# Altium schematic sheet 接口.SchDoc(接口)
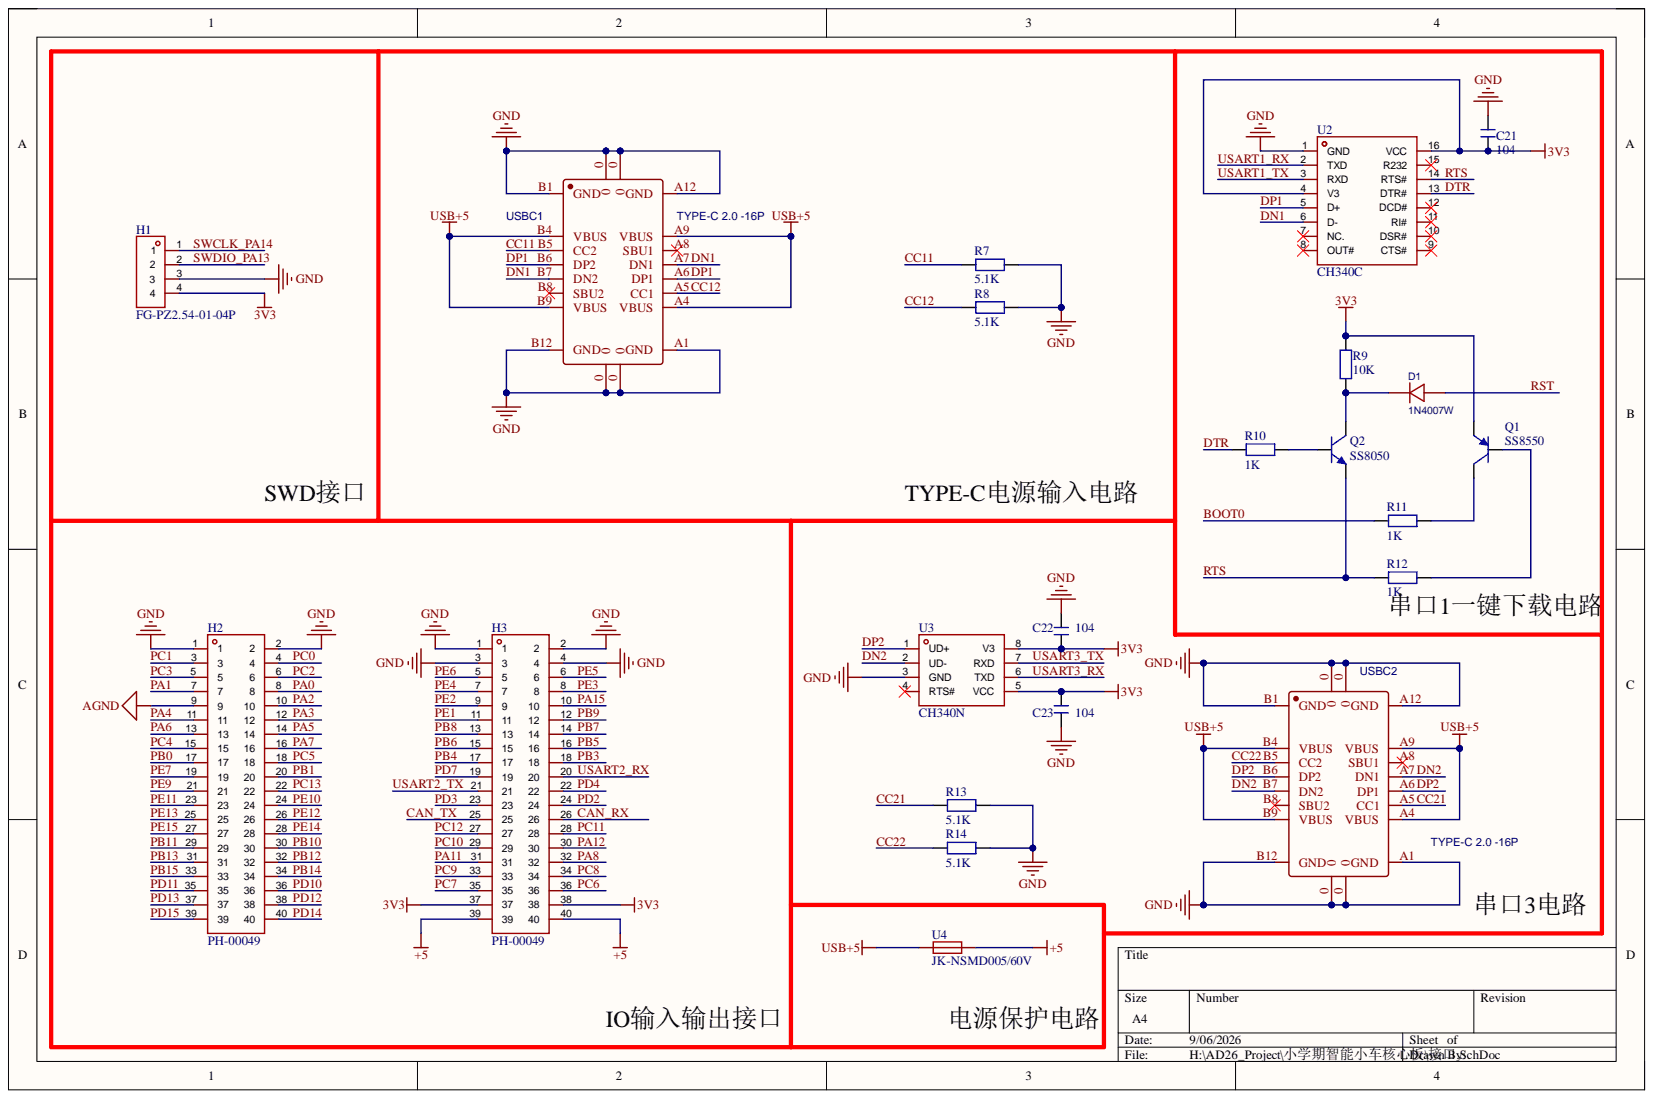
[diagram p0: 接口.SchDoc]

## Parts
C21  104  [C 0805_L]
C22  104  [C 0805_L]
C23  104  [C 0805_L]
D1  1N4007W  [SOD-123FL_L2.7-W1.8-LS3.8-RD]
H1  FG-PZ2.54-01-04P  [HDR-TH_4P-P2.54-V-M]
H2  PH-00049  [HDR-TH_40P-P2.54-V-M-R2-C20-S2.54]
H3  PH-00049  [HDR-TH_40P-P2.54-V-M-R2-C20-S2.54]
Q1  SS8550  [SOT23-3N]
Q2  SS8050  [SOT23-3N]
R7  5.1K  [R 0805_L]
R8  5.1K  [R 0805_L]
R9  10K  [R 0805_L]
R10  1K  [R 0805_L]
R11  1K  [R 0805_L]
R12  1K  [R 0805_L]
R13  5.1K  [R 0805_L]
R14  5.1K  [R 0805_L]
U2  CH340C  [SOP-16_L10.0-W3.9-P1.27-LS6.0-BL]
U3  CH340N  [SOP-8_L4.9-W3.9-P1.27-LS6.0-BL]
U4  JK-NSMD005/60V  [F1206]
USBC1  TYPE-C 2.0 -16P  [USB-C-SMD_TYPE-C-USB-5]
USBC2  TYPE-C 2.0 -16P  [USB-C-SMD_TYPE-C-USB-5]

## Nets
3V3: C21.1 C22.1 C23.2 H1.4 H3.37 H3.38 Q1.2 R9.1 U2.4(V3) U2.16(VCC) U3.5(VCC) U3.8(V3)
+5: H3.39 H3.40 U4.2
AGND: H2.9
GND: C21.2 C22.2 C23.1 H1.3 H2.1 H2.2 H3.1 H3.2 H3.3 H3.4 R7.2 R8.2 R13.2 R14.2 U2.1(GND) U3.3(GND) USBC1.0 USBC1.A1(GND) USBC1.A12(GND) USBC1.B1(GND) USBC1.B12(GND) USBC2.0 USBC2.A1(GND) USBC2.A12(GND) USBC2.B1(GND) USBC2.B12(GND)
USB+5: U4.1 USBC1.A4(VBUS) USBC1.A9(VBUS) USBC1.B4(VBUS) USBC1.B9(VBUS) USBC2.A4(VBUS) USBC2.A9(VBUS) USBC2.B4(VBUS) USBC2.B9(VBUS)
BOOT0: R11.2
CAN_RX: H3.26
CAN_TX: H3.25
CC11: R7.1 USBC1.B5(CC2)
CC12: R8.1 USBC1.A5(CC1)
CC21: R13.1 USBC2.A5(CC1)
CC22: R14.1 USBC2.B5(CC2)
DN1: U2.6(D-) USBC1.A7(DN1) USBC1.B7(DN2)
DN2: U3.2(UD-) USBC2.A7(DN1) USBC2.B7(DN2)
DP1: U2.5(D+) USBC1.A6(DP1) USBC1.B6(DP2)
DP2: U3.1(UD+) USBC2.A6(DP1) USBC2.B6(DP2)
DTR: R10.2 U2.13(DTR#)
PA0: H2.8
PA1: H2.7
PA2: H2.10
PA3: H2.12
PA4: H2.11
PA5: H2.14
PA6: H2.13
PA7: H2.16
PA8: H3.32
PA11: H3.31
PA12: H3.30
PA15: H3.10
PB0: H2.17
PB1: H2.20
PB3: H3.18
PB4: H3.17
PB5: H3.16
PB6: H3.15
PB7: H3.14
PB8: H3.13
PB9: H3.12
PB10: H2.30
PB11: H2.29
PB12: H2.32
PB13: H2.31
PB14: H2.34
PB15: H2.33
PC0: H2.4
PC1: H2.3
PC2: H2.6
PC3: H2.5
PC4: H2.15
PC5: H2.18
PC6: H3.36
PC7: H3.35
PC8: H3.34
PC9: H3.33
PC10: H3.29
PC11: H3.28
PC12: H3.27
PC13: H2.22
PD2: H3.24
PD3: H3.23
PD4: H3.22
PD7: H3.19
PD10: H2.36
PD11: H2.35
PD12: H2.38
PD13: H2.37
PD14: H2.40
PD15: H2.39
PE1: H3.11
PE2: H3.9
PE3: H3.8
PE4: H3.7
PE5: H3.6
PE6: H3.5
PE7: H2.19
PE9: H2.21
PE10: H2.24
PE11: H2.23
PE12: H2.26
PE13: H2.25
PE14: H2.28
PE15: H2.27
RST: D1.2
RTS: Q2.2 R12.2 U2.14(RTS#)
SWCLK_PA14: H1.1
SWDIO_PA13: H1.2
USART1_RX: U2.2(TXD)
USART1_TX: U2.3(RXD)
USART2_RX: H3.20
USART2_TX: H3.21
USART3_RX: U3.6(TXD)
USART3_TX: U3.7(RXD)
NetD1_1: D1.1 Q2.3 R9.2
NetQ1_1: Q1.1 R12.1
NetQ1_3: Q1.3 R11.1
NetQ2_1: Q2.1 R10.1

Not connected: U2 7(NC.) 8(OUT#) 9(CTS#) 10(DSR#) 11(RI#) 12(DCD#) 15(R232); U3 4(RTS#); USBC1 A8(SBU1) B8(SBU2); USBC2 A8(SBU1) B8(SBU2)

## Library data of the parts
C21-C23 = C: 贴片电容; BOM名称: 无极性贴片电容; 淘宝ID: 封装库来自淘宝 ID：黄晶宝石; Component Kind: Standard; Library Name: 0.1 - 电阻-电容-电感.SchLib; 唯一出售店铺网址: https://shop463601444.taobao.com; 联系售后，技术支持: https://shop463601444.taobao.com
D1 = 1N4007W: 二极管配置:独立式;正向压降(Vf):1V@1A;直流反向耐压(Vr):1kV;整流电流:1A;; Manufacturer: R+O(宏嘉诚); AddInBom: yes; Current - Rectified: 1A; Device: 1N4007W_C18199088; Diode Configuration: 独立式; ModelId: 86f9151e155e4fe2af0c4f2e0a80302e聨0819f05c4eef4c71ace90d822a990e87; ModelName: SOD-123FL_L2.8-W1.8-H1.1-LS3.6; ModelTransform: 141.732,70.866,0,180,0,0,0,0,0; Non-Repetitive Peak Forward Surge Current: 30A; Operating Junction Temperature Range: -55℃~+150℃; Reverse Leakage Current (Ir): 2uA@1000V; Voltage - DC Reverse(Vr): 1kV; Voltage - Forward(Vf@If): 1V@1A; Component Kind: Standard; ConvertToPcb: yes; Datasheet: https://item.szlcsc.com/datasheet/1N4007W/19354320.html; FootprintName: SOD-123FL_L2.7-W1.8-LS3.8-RD; JLCPCB Part Class: Extended Part; LCSC Part Name: 1kV 1A; Library Name: 0.2 - 二极管-整流桥.SchLib; ManufacturerPart: 1N4007W; Supplier: LCSC; Supplier Footprint: SOD-123FL; SupplierPart: C18199088; Symbol: 1N4007W_C18199088
H1 = FG-PZ2.54-01-04P: 4*1P排针; Component Kind: Standard; Library Name: 8.1 - 排针-脚距2.54.SchLib
H2 H3 = PH-00049: 20*2P排针; Component Kind: Standard; Library Name: 8.1 - 排针-脚距2.54.SchLib
Q1 = 8550-SMD: 高频放大-PNP型; 属性: 40V 1.5A 1W 100MHZ; 版权归属: 封装库来自淘宝 ID：黄晶宝石; BOM名称: 高频放大-PNP型; Component Kind: Standard; Library Name: 0.4 - 三极管.SchLib; 学习资源: www.ooocke.com
Q2 = 8050-SMD: 高频放大-NPN型; 属性: 40V 1.5A 1W 100MHZ; 版权归属: 封装库来自淘宝 ID：黄晶宝石; BOM名称: 高频放大-NPN型; Component Kind: Standard; Library Name: 0.4 - 三极管.SchLib; 联系售后: https://shop463601444.taobao.com
R7-R14 = R: 贴片电阻; 封装库来自淘宝卖家 ID：黄晶宝石: 其他店铺购入均为盗版，不享受售后技术支持服务，请立即申请退款; 版权归属: 来自淘宝 ID：黄晶宝石; BOM名称: 贴片电阻; Component Kind: Standard; Library Name: 0.1 - 电阻-电容-电感.SchLib; 联系售后: https://shop463601444.taobao.com
U4 = JK-NSMD005/60V: 贴片自恢复保险丝; Component Kind: Standard; Library Name: 2.0 - 保险丝-晶振-光耦.SchLib
USBC1 USBC2 = USB2.0 Type-C 16Pin母座: USB2.0 Type-C 母座 16Pin; BOM_Manufacturer: LCSC; BOM_Manufacturer Part: -; BOM_Supplier: LCSC; Component Kind: Standard; Library Name: 0.8 - 以太网-音频-USB插座.SchLib; spicePre: U; spiceSymbolName: TYPE-C 3.1 -16P_C168689
U2 = CH340C: Component Kind: Standard; Library Name: 1.9 - CH340系列编程器芯片.SchLib
U3 = CH340N: Component Kind: Standard; Library Name: 1.9 - CH340系列编程器芯片.SchLib
